# Altium schematic sheet MiniF4xx_v2.SchDoc(MiniF4xx_v2)
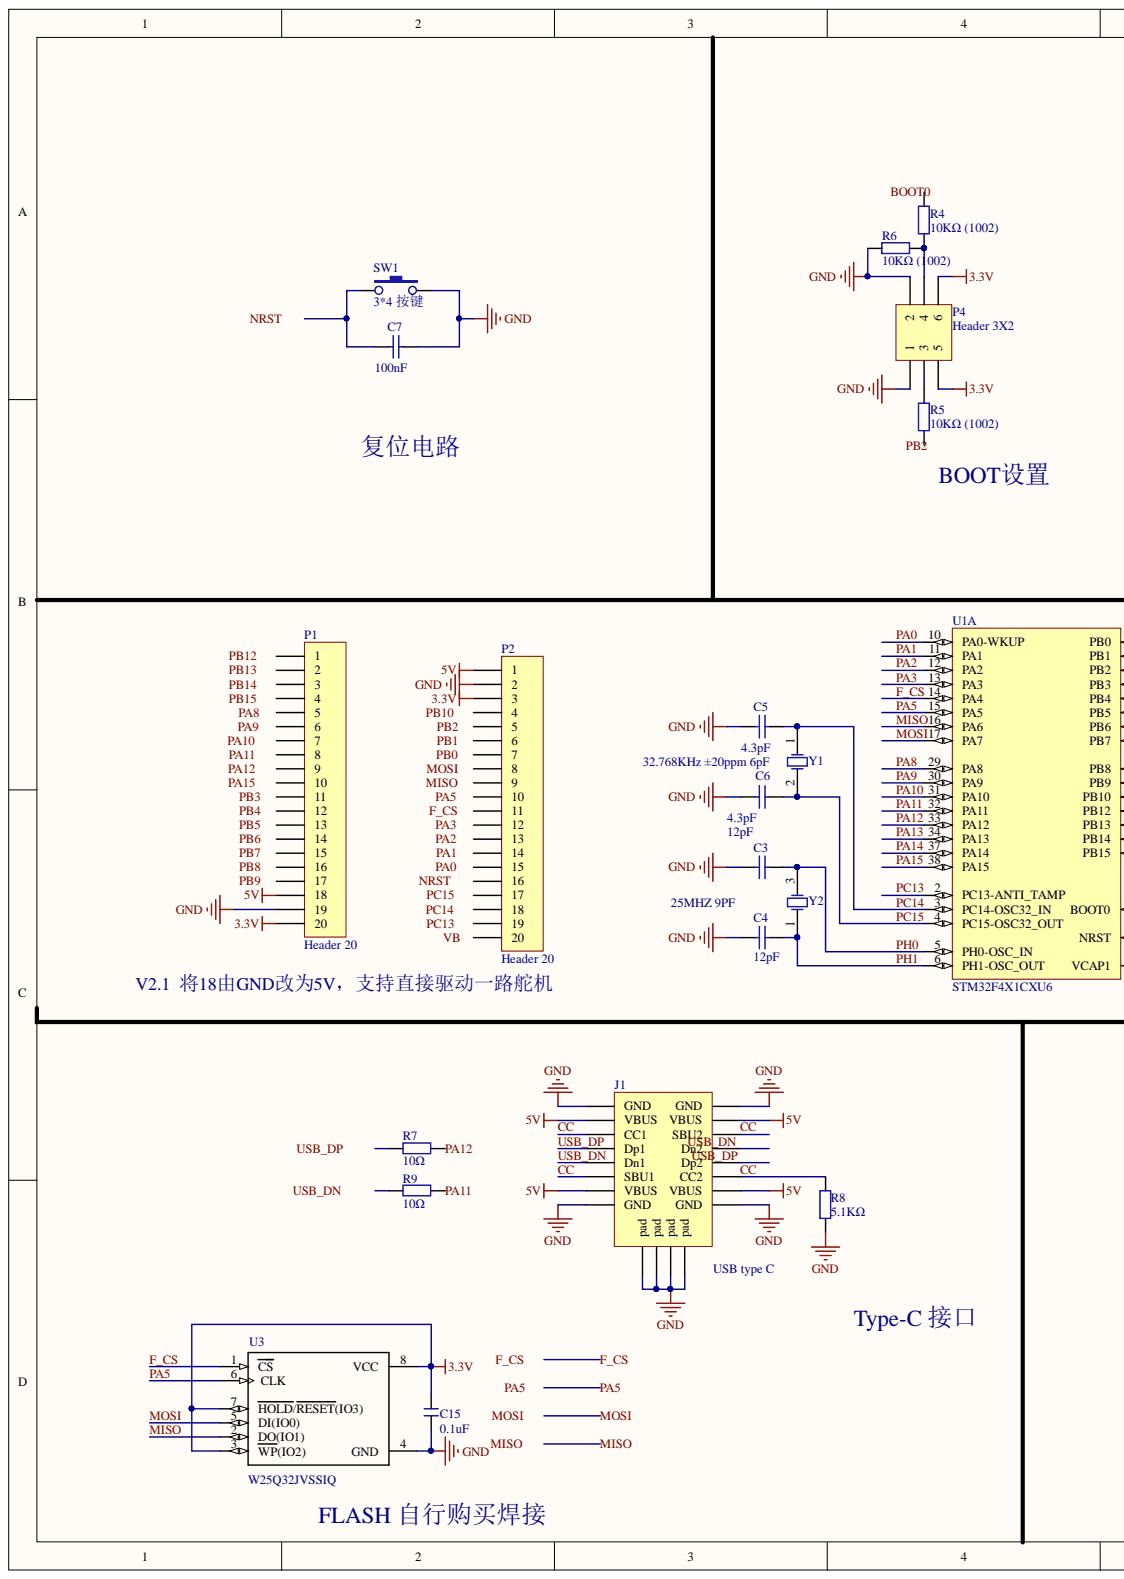
[diagram p0: MiniF4xx_v2.SchDoc, left]
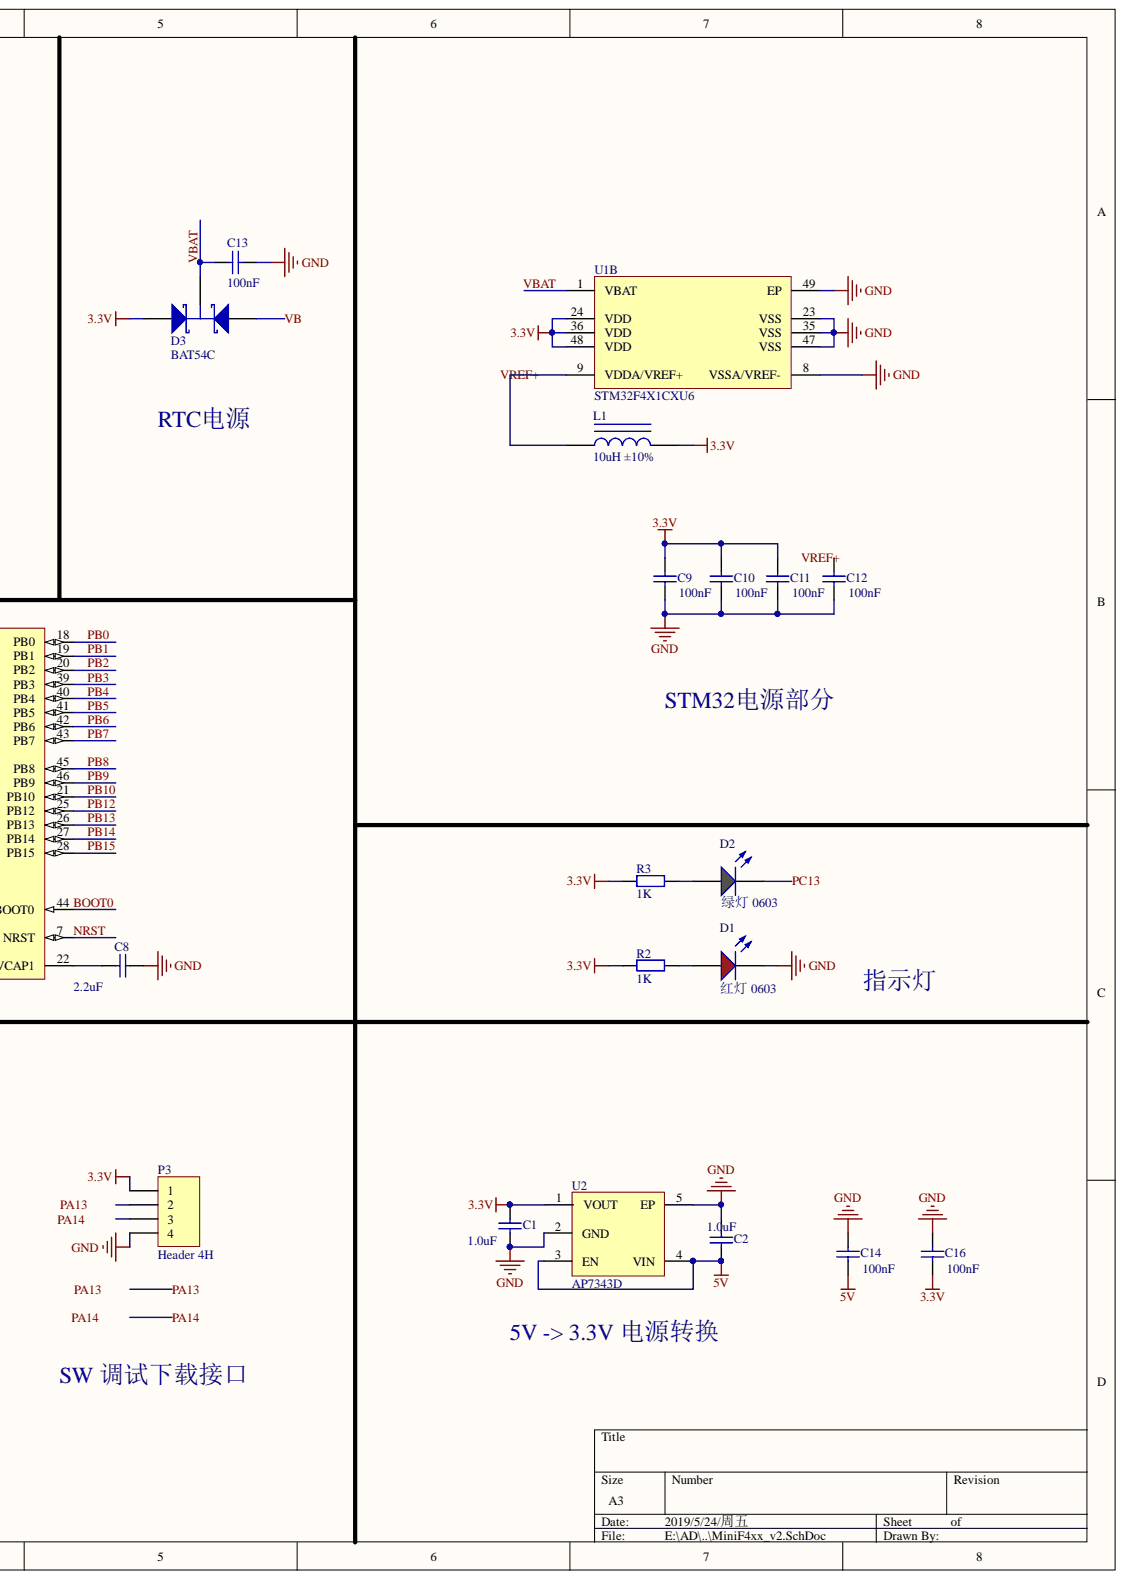
[diagram p1: MiniF4xx_v2.SchDoc, right]

## Parts
C1  1.0uF  [0402_C]
C2  1.0uF  [0402_C]
C3  12pF  [0402_C]
C4  12pF  [0402_C]
C5  4.3pF  [0402_C]
C6  4.3pF  [0402_C]
C7  100nF  [0402_C]
C8  2.2uF  [0402_C]
C9  100nF  [0402_C]
C10  100nF  [0402_C]
C11  100nF  [0402_C]
C12  100nF  [0402_C]
C13  100nF  [0402_C]
C14  100nF  [0402_C]
C15  0.1uF  [C 0402]
C16  100nF  [0402_C]
D1  红灯 0603  Package: 0603  [0603_LED_S1]
D2  绿灯 0603  Package: 0603  [0603_LED_S1]
D3  BAT54C  [SOT23_N]
J1  USB type C  [TYPE-C-USB-17]
L1  10uH ±10%  [0603_L]
P1  Header 20  [HDR1X20]
P2  Header 20  [HDR1X20]
P3  Header 4H  [HDR1X4H]
P4  Header 3X2  [SMD-2*3/1.27]
R2  1K  [0402_R]
R3  1K  [0402_R]
R4  10KΩ (1002)  [0402_R]
R5  10KΩ (1002)  [0402_R]
R6  10KΩ (1002)  [0402_R]
R7  10Ω  [0402_R]
R8  5.1KΩ  [0402_R]
R9  10Ω  [0402_R]
SW1  3*4 按键  [KEY-3.0*4.0_]
U1  STM32F4X1CXU6  [STM-UFQFPN48_N]  (parts A,B)
U2  AP7343D  [X2-DFN1010-4]
U3  W25Q32JVSSIQ  [*SOIC127P790X216-8N]
Y1  32.768KHz ±20ppm 6pF  [OSC-S2X6_3P]
Y2  25MHZ 9PF  [SMD-3225_4P]

## Nets
3.3V: C1.2 C9.2 C10.2 C11.2 C15.1 C16.1 D3.1 L1.2 P1.20 P2.3 P3.1 P4.5 P4.6 R2.1 R3.1 U1.24(VDD) U1.36(VDD) U1.48(VDD) U2.1(VOUT) U3.3(WP(IO2)) U3.7(HOLD/RESET(IO3)) U3.8(VCC)
5V: C2.1 C14.1 J1.A4(VBUS) J1.A9(VBUS) J1.B4(VBUS) J1.B9(VBUS) P1.18 P2.1 U2.3(EN) U2.4(VIN)
GND: C1.1 C2.2 C3.2 C4.2 C5.1 C6.1 C7.2 C8.2 C9.1 C10.1 C11.1 C12.1 C13.1 C14.2 C15.2 C16.2 D1.K J1.0(pad) J1.A1(GND) J1.A12(GND) J1.B1(GND) J1.B12(GND) P1.19 P2.2 P3.4 P4.1 P4.2 R6.2 R8.1 SW1.2 U1.8(VSSA/VREF-) U1.23(VSS) U1.35(VSS) U1.47(VSS) U1.49(EP) U2.2(GND) U2.5(EP) U3.4(GND)
BOOT0: R4.2 U1.44(BOOT0)
CC: J1.A5(CC1) J1.A8(SBU1) J1.B5(CC2) J1.B8(SBU2) R8.2
F_CS: P2.11 U1.14(PA4) U3.1(CS)
MISO: P2.9 U1.16(PA6) U3.2(DO(IO1))
MOSI: P2.8 U1.17(PA7) U3.5(DI(IO0))
NRST: C7.1 P2.16 SW1.1 U1.7(NRST)
PA0: P2.15 U1.10(PA0-WKUP)
PA1: P2.14 U1.11(PA1)
PA2: P2.13 U1.12(PA2)
PA3: P2.12 U1.13(PA3)
PA5: P2.10 U1.15(PA5) U3.6(CLK)
PA8: P1.5 U1.29(PA8)
PA9: P1.6 U1.30(PA9)
PA10: P1.7 U1.31(PA10)
PA11: P1.8 R9.1 U1.32(PA11)
PA12: P1.9 R7.1 U1.33(PA12)
PA13: P3.2 U1.34(PA13)
PA14: P3.3 U1.37(PA14)
PA15: P1.10 U1.38(PA15)
PB0: P2.7 U1.18(PB0)
PB1: P2.6 U1.19(PB1)
PB2: P2.5 R5.1 U1.20(PB2)
PB3: P1.11 U1.39(PB3)
PB4: P1.12 U1.40(PB4)
PB5: P1.13 U1.41(PB5)
PB6: P1.14 U1.42(PB6)
PB7: P1.15 U1.43(PB7)
PB8: P1.16 U1.45(PB8)
PB9: P1.17 U1.46(PB9)
PB10: P2.4 U1.21(PB10)
PB12: P1.1 U1.25(PB12)
PB13: P1.2 U1.26(PB13)
PB14: P1.3 U1.27(PB14)
PB15: P1.4 U1.28(PB15)
PC13: D2.K P2.19 U1.2(PC13-ANTI_TAMP)
PC14: C5.2 P2.18 U1.3(PC14-OSC32_IN) Y1.1
PC15: C6.2 P2.17 U1.4(PC15-OSC32_OUT) Y1.2
PH0: C3.1 U1.5(PH0-OSC_IN) Y2.3
PH1: C4.1 U1.6(PH1-OSC_OUT) Y2.1
USB_DN: J1.A7(Dn1) J1.B7(Dn2) R9.2
USB_DP: J1.A6(Dp1) J1.B6(Dp2) R7.2
VB: D3.2 P2.20
VBAT: C13.2 D3.3 U1.1(VBAT)
VREF+: C12.2 L1.1 U1.9(VDDA/VREF+)
NetC8_1: C8.1 U1.22(VCAP1)
NetD1_A: D1.A R2.2
NetD2_A: D2.A R3.2
NetP4_3: P4.3 R5.2
NetP4_4: P4.4 R4.1 R6.1

## Library data of the parts
C1 C2 = 0402 1.0uF (105) 10% 25V: 贴片电容; SuppliersPartNumber: C52923; Supplier: LC; Package: 0402; Library Name: Cap.SchLib; ComponentLink1URL: http://www.szlcsc.com/product/details_53938.html; ComponentLink1Description: 供应商链接; Component Kind: Standard
C3-C6 = 0402 20pF (200) 5% 50V: 贴片电容; SuppliersPartNumber: C1554; Supplier: LC; Package: 0402; Library Name: Cap.SchLib; ComponentLink1URL: http://www.szlcsc.com/product/details_1906.html; ComponentLink1Description: 供应商链接; Component Kind: Standard
C7 C9-C14 C16 = 0402 100nF (104) 10% 16V: 贴片电容; SuppliersPartNumber: C1525; Supplier: LC; Package: 0402; Library Name: Cap.SchLib; ComponentLink1URL: http://www.szlcsc.com/product/details_1877.html; ComponentLink1Description: 供应商链接; Component Kind: Standard
C8 = 0402 4.7uF (475) 20% 10V: 贴片电容; SuppliersPartNumber: C23733; Supplier: LC; Package: 0402; Library Name: Cap.SchLib; ComponentLink1URL: http://www.szlcsc.com/product/details_24469.html; ComponentLink1Description: 供应商链接; Component Kind: Standard
C15 = C: 无极性贴片电容; 版权归属: 源创客; 学习资源: www.ooocke.com; Library Name: PMW3901 V1.1.SCHLIB; Component Kind: Standard; BOM名称: 无极性贴片电容
D1 = 0603 红灯: 发光二极管; SuppliersPartNumber: C2286; Supplier: LC; Library Name: LED.SchLib; ComponentLink1URL: http://www.szlcsc.com/product/details_2659.html; ComponentLink1Description: 供应商链接; Component Kind: Standard
D2 = 0603 绿灯: 发光二极管; SuppliersPartNumber: C72043; Supplier: LC; Library Name: LED.SchLib; ComponentLink1URL: http://www.szlcsc.com/product/details_73152.html; ComponentLink1Description: 供应商链接; Component Kind: Standard
D3 = BAT54C: Schottky Barrier Double Diode; Simulation: BAT54C; Signal Integrity: BAT54C; Published: 17-Sep-2003; PackageVersion: May-1999; PackageReference: SOT23; PackageDescription: 3-Pin SOT-23 Package 0.95 mm Pitch, 2.3 mm Lead Span; Library Name: MiniF401CB.IntLib; LatestRevisionNote: IPC-7351 Footprint Added.; LatestRevisionDate: 12-Dec-2006; DatasheetVersion: 4-Mar-2002; ComponentLink2URL: http://www.semiconductors.philips.com/acrobat/datasheets/BAT54_SERIES_4.pdf; ComponentLink2Description: Datasheet; ComponentLink1URL: http://www.semiconductors.philips.com/; ComponentLink1Description: Manufacturer Link; Component Kind: Standard; Publisher: Altium Limited
J1 = USB_C: Component Kind: Standard; Library Name: LicheeDan.SCHLIB
L1 = 0603 4.7uH 10%: 贴片电感; SuppliersPartNumber: C1034; Supplier: LC; Package: 0603; Library Name: IUD.SchLib; ComponentLink1URL: http://www.szlcsc.com/product/details_1386.html; ComponentLink1Description: 供应商链接; Component Kind: Standard
P1 P2 = Header 20: Header, 20-Pin; Signal Integrity: Connector; Library Name: Miscellaneous Connectors.IntLib; LatestRevisionNote: Re-released for DXP Platform.; LatestRevisionDate: 17-Jul-2002; Component Kind: Standard; Publisher: Altium Limited
P3 = Header 4H: Header, 4-Pin, Right Angle; Signal Integrity: Connector; Library Name: Miscellaneous Connectors.IntLib; LatestRevisionNote: Re-released for DXP Platform.; LatestRevisionDate: 17-Jul-2002; Component Kind: Standard; Publisher: Altium Limited
P4 = Header 3X2: Header, 3-Pin, Dual row; Signal Integrity: Connector; Library Name: Miscellaneous Connectors.IntLib; LatestRevisionNote: Re-released for DXP Platform.; LatestRevisionDate: 17-Jul-2002; Component Kind: Standard; Publisher: Altium Limited
R2 R3 = 0402 510KΩ (5103) 1%: 贴片电阻; SuppliersPartNumber: C11616; Supplier: LC; Package: 0402; Library Name: RES.SchLib; ComponentLink1URL: http://www.szlcsc.com/product/details_12170.html; ComponentLink1Description: 供应商链接; Component Kind: Standard
R4-R7 R9 = 0402 10KΩ (1002) 1%: 贴片电阻; SuppliersPartNumber: C25744; Supplier: LC; Package: 0402; Library Name: RES.SchLib; ComponentLink1URL: http://www.szlcsc.com/product/details_26487.html; ComponentLink1Description: 供应商链接; Component Kind: Standard
R8 = 0402 5.1KΩ (5101) 1%: 贴片电阻; SuppliersPartNumber: C25905; Supplier: LC; Package: 0402; Library Name: RES.SchLib; ComponentLink1URL: http://www.szlcsc.com/product/details_26648.html; ComponentLink1Description: 供应商链接; Component Kind: Standard
SW1 = SW-PB_V: SWITCH; Library Name: Integrated_Library1.IntLib; Component Kind: Standard
U1 = STM32F401CBU6: ARM Cortex-M4 32-bit MCU+FPU, 105 DMIPS, 128 kB Flash, 64 kB Internal RAM, 36 I/Os, 48-pin UFQFPN, -40 to 85 degC, Tray; Manufacturer: STMicroelectronics; Supply Voltage(Vcc) min (V): 1.8; Supply Voltage(Vcc) max (V): 3.6; Operating Frequency(F) (Processor speed) (MHz): 84; Internal RAM Size (kB): 64; I/Os (High Current): 36; FLASH Size (Prog) (kB): 128; Supplier Part Number 2: 511-STM32F401CBU6; Supplier Part Number 1: 19X6215; Supplier 2: Mouser; Supplier 1: Newark; PartNumber: STM32F401CBU6; Packing: Tray; PackageVersion: Rev. 1, 04/2013; PackageReference: UFQFPN48; PackageDescription: 48-Pin Ultra Thin Fine Pitch Quad Flat No-Lead, 7 x 7 x 0.6 mm Body, 0.5 mm Pitch, Exposed Pad; Mounting Technology: Surface Mount; Library Name: STMicroelectronics STM32 F4.IntLib; DatasheetVersion: Rev. 1; Core: ARM Cortex-M4; ComponentLink3URL: http://www.st.com/st-web-ui/static/active/en/resource/technical/document/data_brief/DM00071938.pdf; ComponentLink3Description: Package Specification; ComponentLink2URL: http://www.st.com/st-web-ui/static/active/en/resource/technical/document/data_brief/DM00071938.pdf; ComponentLink2Description: Datasheet; ComponentLink1URL: http://www.st.com/web/en/home.html; ComponentLink1Description: Manufacturer URL; Component Kind: Standard
U2 = AD7343-DFN: Component Kind: Standard; Library Name: zhuyix.IntLib
U3 = W25Q32JVSSIQ: NOR闂瓨涓茶SPI 3V 32Mbit 32M x 1浣?寮曡剼SOIC; MF: Winbond; SnapEDA_Link: https://www.snapeda.com/parts/W25Q32JVSSIQ/Winbond%20Electronics/view-part/?ref=snap; Price: None; Package: SOIC-8 Winbond; MP: W25Q32JVSSIQ; Library Name: W25Q32JVSSIQ.IntLib; Component Kind: Standard; Availability: Unavailable
Y1 = SMD-3215_2P 32.768KHz 20ppm 12.5pF: 贴片晶振; SuppliersPartNumber: C32346; Supplier: LC; Package: SMD-3215_2P; Library Name: CrystalOscillator.SchLib; ComponentLink1URL: http://www.szlcsc.com/product/details_33310.html; ComponentLink1Description: 供应商链接; Component Kind: Standard
Y2 = SMD-3225_4P  25MHZ 12PF 10PPM: 贴片晶振; SuppliersPartNumber: C9006; Supplier: LC; Package: SMD-3225_4P; Library Name: CrystalOscillator.SchLib; ComponentLink1URL: http://www.szlcsc.com/product/details_9526.html; ComponentLink1Description: 供应商链接; Component Kind: Standard
